# Altium schematic sheet 04_Analog_Part.SchDoc(04_Analog_Part)
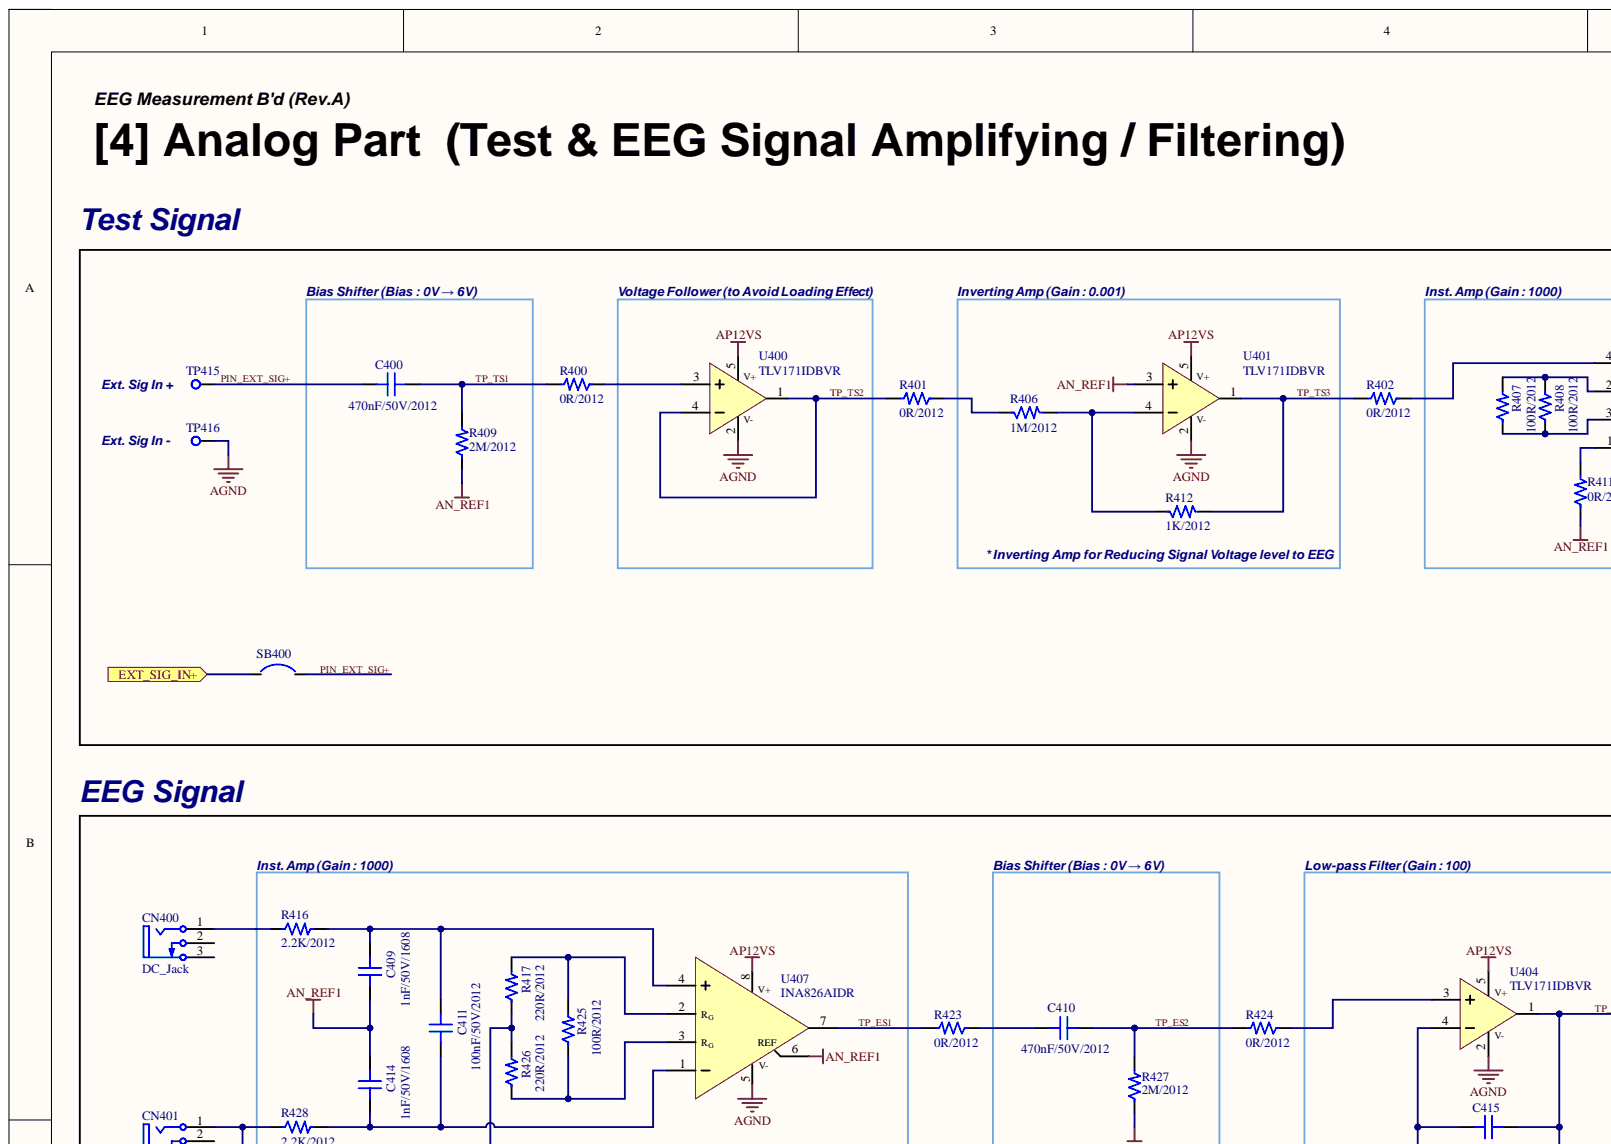
[diagram p0: 04_Analog_Part.SchDoc, top left]
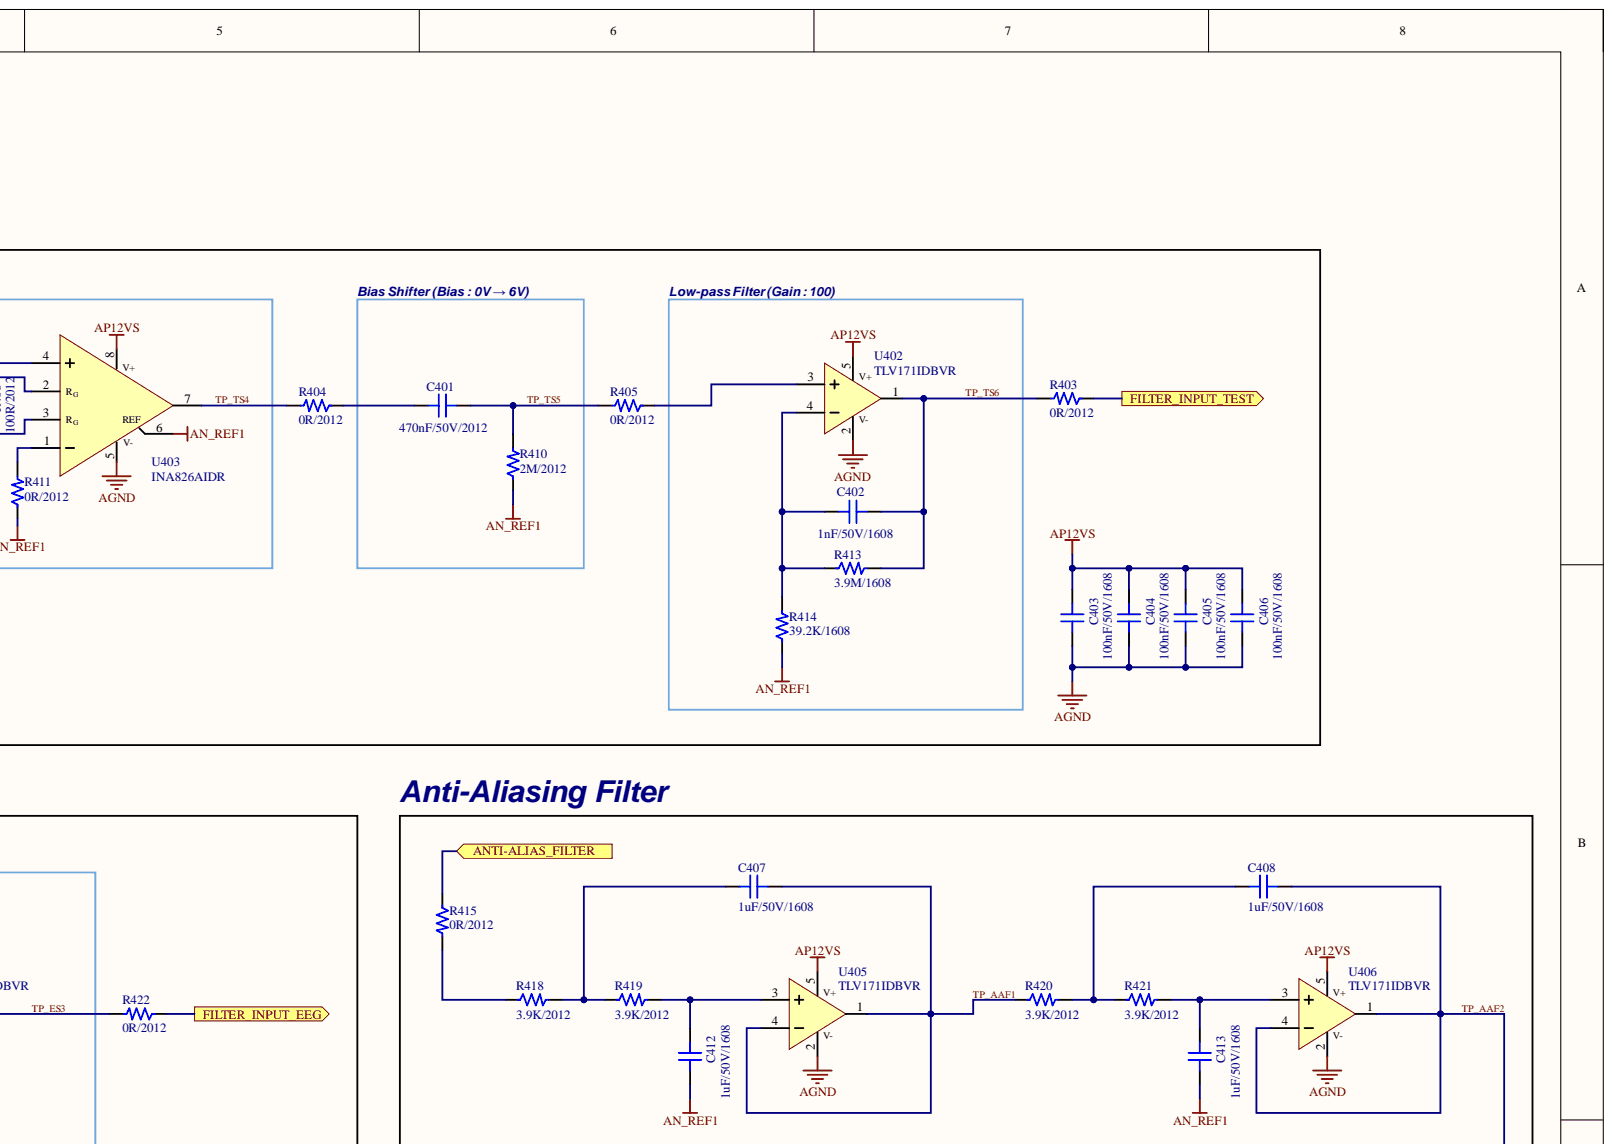
[diagram p1: 04_Analog_Part.SchDoc, top right]
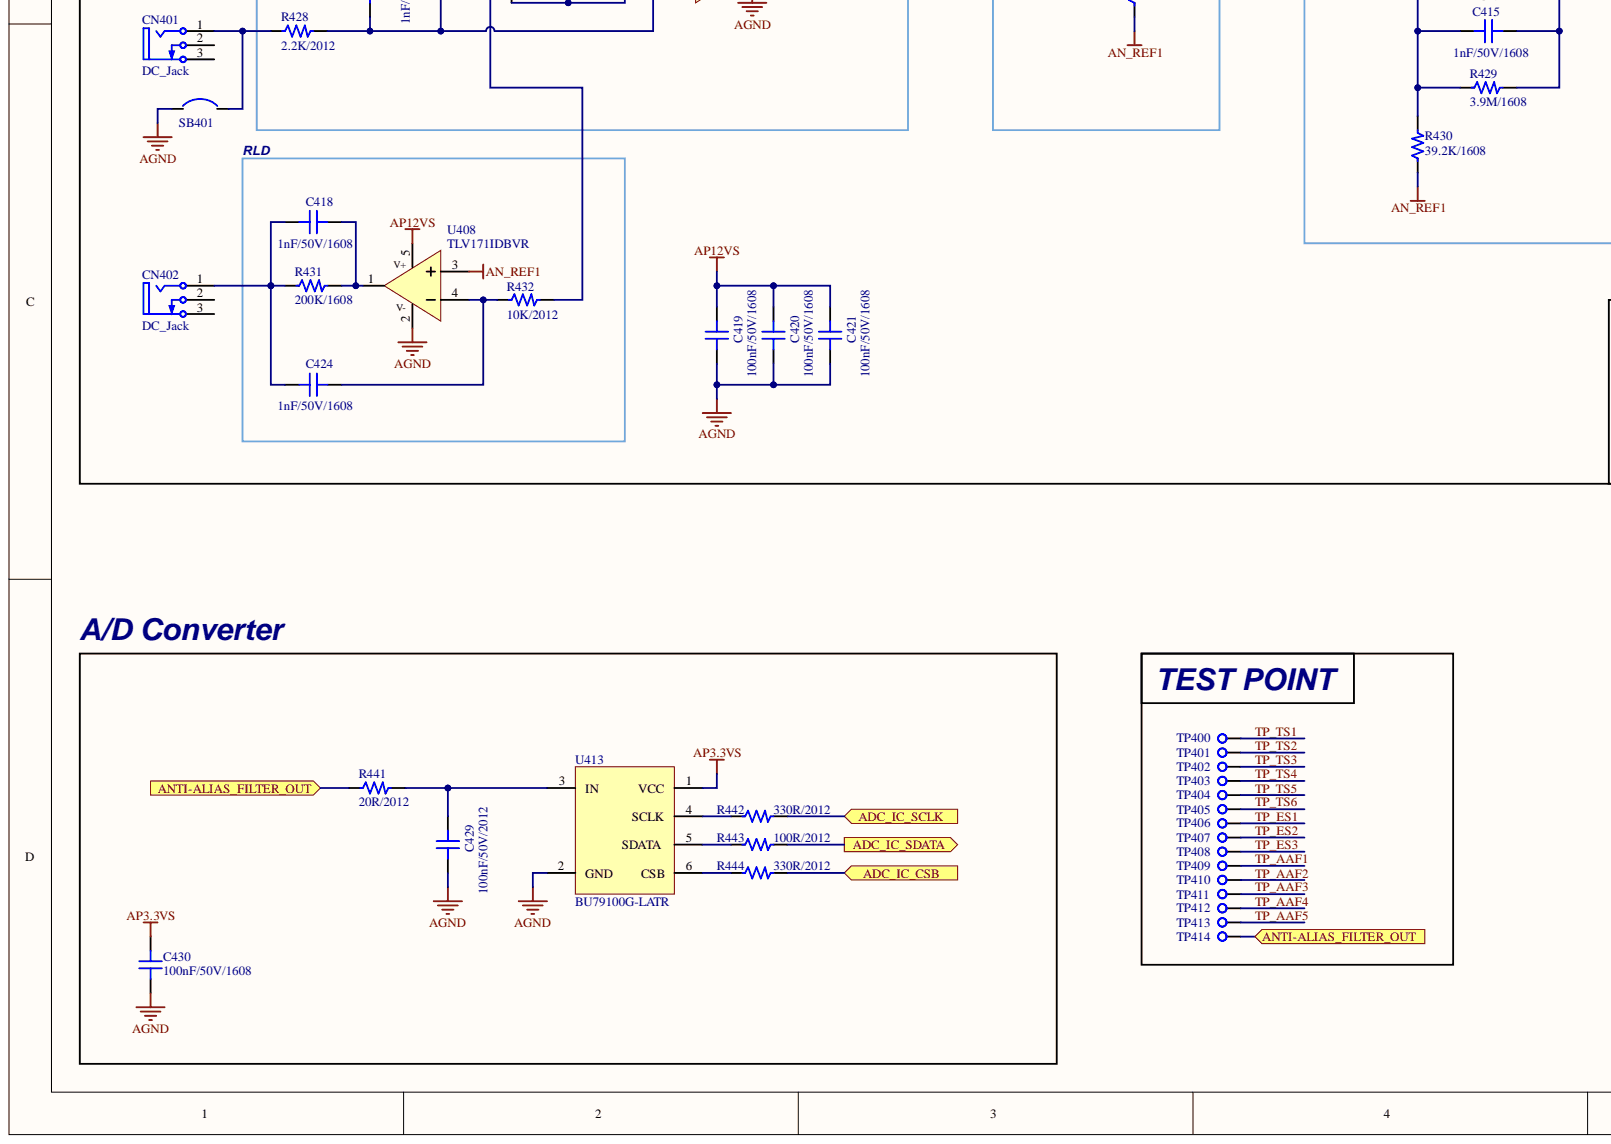
[diagram p2: 04_Analog_Part.SchDoc, bottom left]
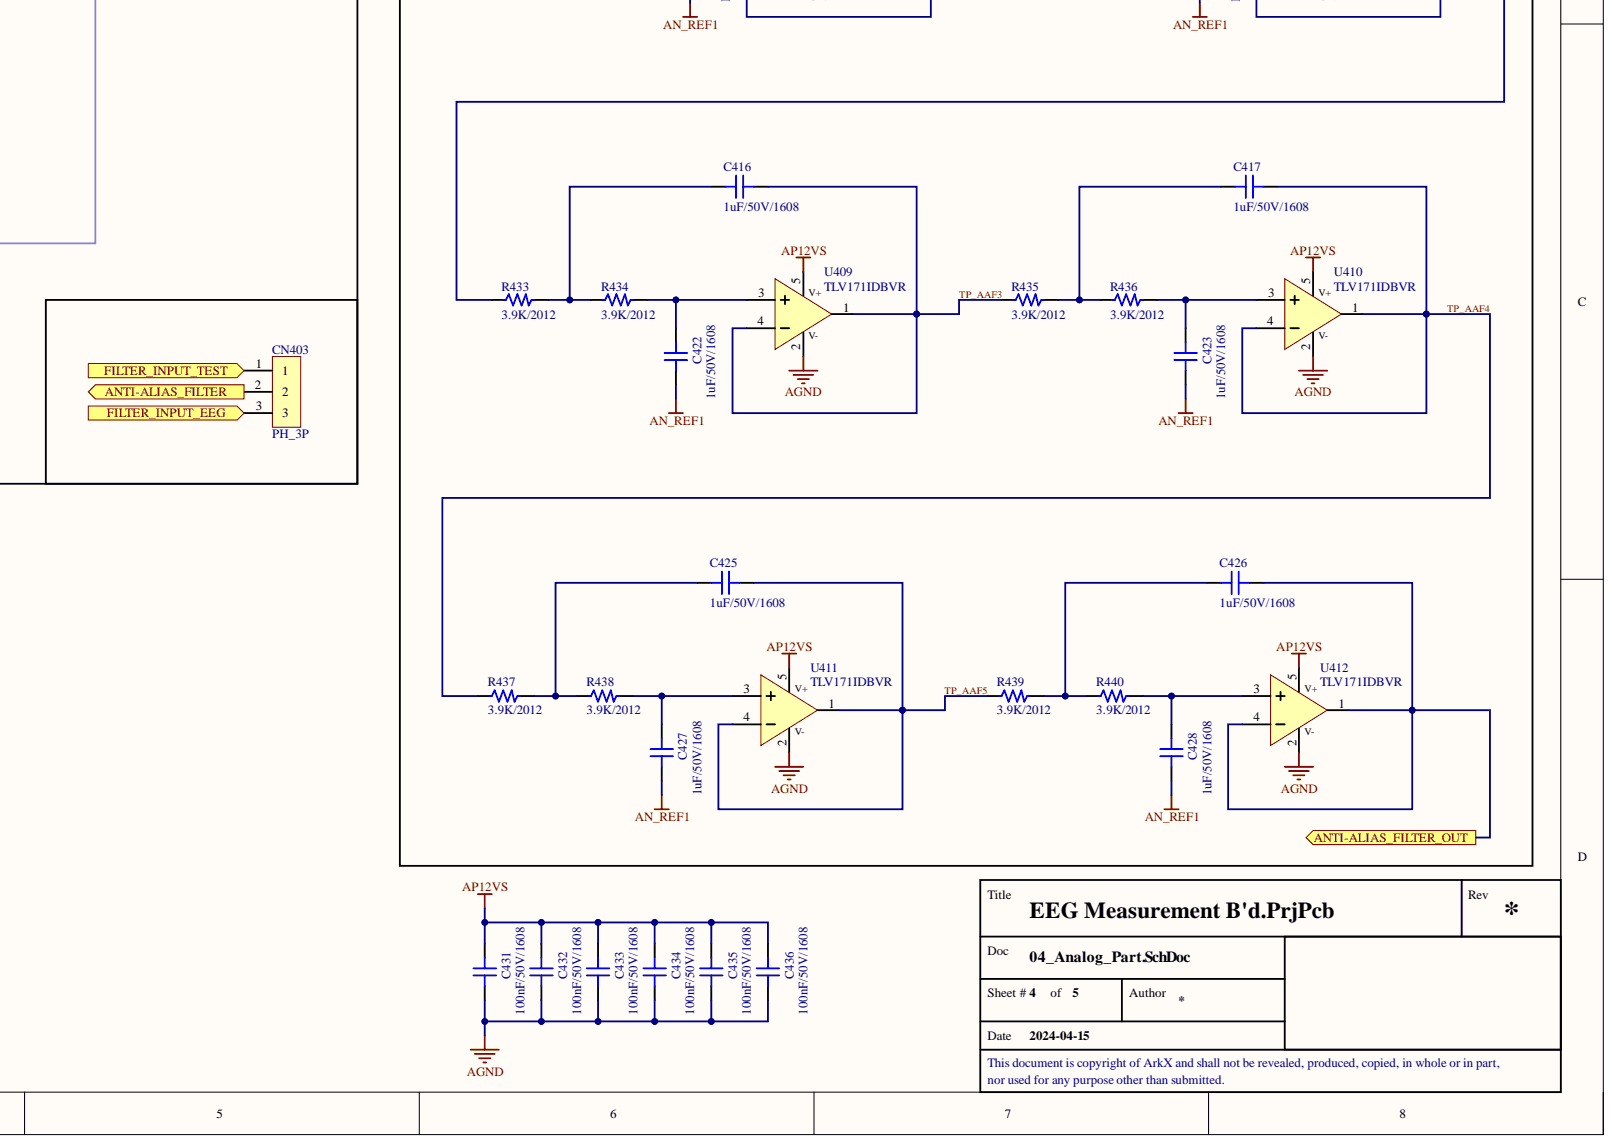
[diagram p3: 04_Analog_Part.SchDoc, bottom right]

## Parts
C400  470nF/50V/2012  [CAP 2012 (0805_INCH)]
C401  470nF/50V/2012  [CAP 2012 (0805_INCH)]
C402  1nF/50V/1608  [CAP 1608 (0603_INCH)]
C403  100nF/50V/1608  [CAP 1608 (0603_INCH)]
C404  100nF/50V/1608  [CAP 1608 (0603_INCH)]
C405  100nF/50V/1608  [CAP 1608 (0603_INCH)]
C406  100nF/50V/1608  [CAP 1608 (0603_INCH)]
C407  1uF/50V/1608  [CAP 1608 (0603_INCH)]
C408  1uF/50V/1608  [CAP 1608 (0603_INCH)]
C409  1nF/50V/1608  [CAP 1608 (0603_INCH)]
C410  470nF/50V/2012  [CAP 2012 (0805_INCH)]
C411  100nF/50V/2012  [CAP 2012 (0805_INCH)]
C412  1uF/50V/1608  [CAP 1608 (0603_INCH)]
C413  1uF/50V/1608  [CAP 1608 (0603_INCH)]
C414  1nF/50V/1608  [CAP 1608 (0603_INCH)]
C415  1nF/50V/1608  [CAP 1608 (0603_INCH)]
C416  1uF/50V/1608  [CAP 1608 (0603_INCH)]
C417  1uF/50V/1608  [CAP 1608 (0603_INCH)]
C418  1nF/50V/1608  [CAP 1608 (0603_INCH)]
C419  100nF/50V/1608  [CAP 1608 (0603_INCH)]
C420  100nF/50V/1608  [CAP 1608 (0603_INCH)]
C421  100nF/50V/1608  [CAP 1608 (0603_INCH)]
C422  1uF/50V/1608  [CAP 1608 (0603_INCH)]
C423  1uF/50V/1608  [CAP 1608 (0603_INCH)]
C424  1nF/50V/1608  [CAP 1608 (0603_INCH)]
C425  1uF/50V/1608  [CAP 1608 (0603_INCH)]
C426  1uF/50V/1608  [CAP 1608 (0603_INCH)]
C427  1uF/50V/1608  [CAP 1608 (0603_INCH)]
C428  1uF/50V/1608  [CAP 1608 (0603_INCH)]
C429  100nF/50V/2012  [CAP 2012 (0805_INCH)]
C430  100nF/50V/1608  [CAP 1608 (0603_INCH)]
C431  100nF/50V/1608  [CAP 1608 (0603_INCH)]
C432  100nF/50V/1608  [CAP 1608 (0603_INCH)]
C433  100nF/50V/1608  [CAP 1608 (0603_INCH)]
C434  100nF/50V/1608  [CAP 1608 (0603_INCH)]
C435  100nF/50V/1608  [CAP 1608 (0603_INCH)]
C436  100nF/50V/1608  [CAP 1608 (0603_INCH)]
CN400  DC_Jack  [CON DC-Jack]
CN401  DC_Jack  [CON DC-Jack]
CN402  DC_Jack  [CON DC-Jack]
CN403  PH_3P  [CON PIN_HEADER-3PIN(2.54MM)]
R400  0R/2012  [RES 2012 (0805_inch)]
R401  0R/2012  [RES 2012 (0805_inch)]
R402  0R/2012  [RES 2012 (0805_inch)]
R403  0R/2012  [RES 2012 (0805_inch)]
R404  0R/2012  [RES 2012 (0805_inch)]
R405  0R/2012  [RES 2012 (0805_inch)]
R406  1M/2012  [RES 2012 (0805_inch)]
R407  100R/2012  [RES 2012 (0805_inch)]
R408  100R/2012  [RES 2012 (0805_inch)]
R409  2M/2012  [RES 2012 (0805_inch)]
R410  2M/2012  [RES 2012 (0805_inch)]
R411  0R/2012  [RES 2012 (0805_inch)]
R412  1K/2012  [RES 2012 (0805_inch)]
R413  3.9M/1608  [RES 1608 (0603_inch)]
R414  39.2K/1608  [RES 1608 (0603_inch)]
R415  0R/2012  [RES 2012 (0805_inch)]
R416  2.2K/2012  [RES 2012 (0805_inch)]
R417  220R/2012  [RES 2012 (0805_inch)]
R418  3.9K/2012  [RES 2012 (0805_inch)]
R419  3.9K/2012  [RES 2012 (0805_inch)]
R420  3.9K/2012  [RES 2012 (0805_inch)]
R421  3.9K/2012  [RES 2012 (0805_inch)]
R422  0R/2012  [RES 2012 (0805_inch)]
R423  0R/2012  [RES 2012 (0805_inch)]
R424  0R/2012  [RES 2012 (0805_inch)]
R425  100R/2012  [RES 2012 (0805_inch)]
R426  220R/2012  [RES 2012 (0805_inch)]
R427  2M/2012  [RES 2012 (0805_inch)]
R428  2.2K/2012  [RES 2012 (0805_inch)]
R429  3.9M/1608  [RES 1608 (0603_inch)]
R430  39.2K/1608  [RES 1608 (0603_inch)]
R431  200K/1608  [RES 1608 (0603_inch)]
R432  10K/2012  [RES 2012 (0805_inch)]
R433  3.9K/2012  [RES 2012 (0805_inch)]
R434  3.9K/2012  [RES 2012 (0805_inch)]
R435  3.9K/2012  [RES 2012 (0805_inch)]
R436  3.9K/2012  [RES 2012 (0805_inch)]
R437  3.9K/2012  [RES 2012 (0805_inch)]
R438  3.9K/2012  [RES 2012 (0805_inch)]
R439  3.9K/2012  [RES 2012 (0805_inch)]
R440  3.9K/2012  [RES 2012 (0805_inch)]
R441  20R/2012  [RES 3216 (1206_inch)]
R442  330R/2012  [RES 2012 (0805_inch)]
R443  100R/2012  [RES 2012 (0805_inch)]
R444  330R/2012  [RES 2012 (0805_inch)]
SB400  SB  [SB (Solder-Bridge)]
SB401  SB  [SB (Solder-Bridge)]
TP400  TP_HOLE(1MM)  [TP_HOLE(1MM)]  (value not drawn)
TP401  TP_HOLE(1MM)  [TP_HOLE(1MM)]  (value not drawn)
TP402  TP_HOLE(1MM)  [TP_HOLE(1MM)]  (value not drawn)
TP403  TP_HOLE(1MM)  [TP_HOLE(1MM)]  (value not drawn)
TP404  TP_HOLE(1MM)  [TP_HOLE(1MM)]  (value not drawn)
TP405  TP_HOLE(1MM)  [TP_HOLE(1MM)]  (value not drawn)
TP406  TP_HOLE(1MM)  [TP_HOLE(1MM)]  (value not drawn)
TP407  TP_HOLE(1MM)  [TP_HOLE(1MM)]  (value not drawn)
TP408  TP_HOLE(1MM)  [TP_HOLE(1MM)]  (value not drawn)
TP409  TP_HOLE(1MM)  [TP_HOLE(1MM)]  (value not drawn)
TP410  TP_HOLE(1MM)  [TP_HOLE(1MM)]  (value not drawn)
TP411  TP_HOLE(1MM)  [TP_HOLE(1MM)]  (value not drawn)
TP412  TP_HOLE(1MM)  [TP_HOLE(1MM)]  (value not drawn)
TP413  TP_HOLE(1MM)  [TP_HOLE(1MM)]  (value not drawn)
TP414  TP_HOLE(1MM)  [TP_HOLE(1MM)]  (value not drawn)
TP415  TP_HOLE(1MM)  [TP_HOLE(1MM)]  (value not drawn)
TP416  TP_HOLE(1MM)  [TP_HOLE(1MM)]  (value not drawn)
U400  TLV171IDBVR  [SOT-23-5 (TI DBV PACKAGE)]
U401  TLV171IDBVR  [SOT-23-5 (TI DBV PACKAGE)]
U402  TLV171IDBVR  [SOT-23-5 (TI DBV PACKAGE)]
U403  INA826AIDR  [IC_SOIC-8 (4.90x3.90mm)]
U404  TLV171IDBVR  [SOT-23-5 (TI DBV PACKAGE)]
U405  TLV171IDBVR  [SOT-23-5 (TI DBV PACKAGE)]
U406  TLV171IDBVR  [SOT-23-5 (TI DBV PACKAGE)]
U407  INA826AIDR  [IC_SOIC-8 (4.90x3.90mm)]
U408  TLV171IDBVR  [SOT-23-5 (TI DBV PACKAGE)]
U409  TLV171IDBVR  [SOT-23-5 (TI DBV PACKAGE)]
U410  TLV171IDBVR  [SOT-23-5 (TI DBV PACKAGE)]
U411  TLV171IDBVR  [SOT-23-5 (TI DBV PACKAGE)]
U412  TLV171IDBVR  [SOT-23-5 (TI DBV PACKAGE)]
U413  BU79100G-LATR  [IC_SOT-23-6]

## Ports
ADC_IC_CSB, ADC_IC_SCLK, ADC_IC_SDATA, ANTI-ALIAS_FILTER, ANTI-ALIAS_FILTER_OUT, EXT_SIG_IN+, FILTER_INPUT_EEG, FILTER_INPUT_TEST

## Nets
AGND: C403.1 C404.1 C405.1 C406.1 C419.1 C420.1 C421.1 C429.1 C430.1 C431.1 C432.1 C433.1 C434.1 C435.1 C436.1 SB401.1 TP416.1 U400.2 U401.2 U402.2 U403.5 U404.2 U405.2 U406.2 U407.5 U408.2 U409.2 U410.2 U411.2 U412.2 U413.2(GND)
AN_REF1: C409.1 C412.1 C413.1 C414.2 C422.1 C423.1 C427.1 C428.1 R409.1 R410.1 R411.1 R414.1 R427.1 R430.1 U401.3 U403.6 U407.6 U408.3
AP3.3VS: C430.2 U413.1(VCC)
AP12VS: C403.2 C404.2 C405.2 C406.2 C419.2 C420.2 C421.2 C431.2 C432.2 C433.2 C434.2 C435.2 C436.2 U400.5 U401.5 U402.5 U403.8 U404.5 U405.5 U406.5 U407.8 U408.5 U409.5 U410.5 U411.5 U412.5
ADC_IC_CSB: R444.2 ; port ADC_IC_CSB
ADC_IC_SCLK: R442.2 ; port ADC_IC_SCLK
ADC_IC_SDATA: R443.2 ; port ADC_IC_SDATA
ANTI-ALIAS_FILTER: CN403.2 R415.1 ; port ANTI-ALIAS_FILTER
ANTI-ALIAS_FILTER_OUT: C426.2 R441.1 TP414.1 U412.1 U412.4 ; port ANTI-ALIAS_FILTER_OUT
EXT_SIG_IN+: SB400.1 ; port EXT_SIG_IN+
FILTER_INPUT_EEG: CN403.3 R422.1 ; port FILTER_INPUT_EEG
FILTER_INPUT_TEST: CN403.1 R403.1 ; port FILTER_INPUT_TEST
PIN_EXT_SIG+: C400.1 SB400.2 TP415.1
TP_AAF1: C407.2 R420.1 TP409.1 U405.1 U405.4
TP_AAF2: C408.2 R433.1 TP410.1 U406.1 U406.4
TP_AAF3: C416.2 R435.1 TP411.1 U409.1 U409.4
TP_AAF4: C417.2 R437.1 TP412.1 U410.1 U410.4
TP_AAF5: C425.2 R439.1 TP413.1 U411.1 U411.4
TP_ES1: R423.2 TP406.1 U407.7
TP_ES2: C410.2 R424.2 R427.2 TP407.1
TP_ES3: C415.1 R422.2 R429.2 TP408.1 U404.1
TP_TS1: C400.2 R400.2 R409.2 TP400.1
TP_TS2: R401.2 TP401.1 U400.1 U400.4
TP_TS3: R402.2 R412.2 TP402.1 U401.1
TP_TS4: R404.2 TP403.1 U403.7
TP_TS5: C401.2 R405.2 R410.2 TP404.1
TP_TS6: C402.1 R403.2 R413.2 TP405.1 U402.1
NetC401_1: C401.1 R404.1
NetC402_2: C402.2 R413.1 R414.2 U402.4
NetC407_1: C407.1 R418.2 R419.1
NetC408_1: C408.1 R420.2 R421.1
NetC409_2: C409.2 C411.2 R416.2 U407.4
NetC410_1: C410.1 R423.1
NetC411_1: C411.1 C414.1 R428.2 U407.1
NetC412_2: C412.2 R419.2 U405.3
NetC413_2: C413.2 R421.2 U406.3
NetC415_2: C415.2 R429.1 R430.2 U404.4
NetC416_1: C416.1 R433.2 R434.1
NetC417_1: C417.1 R435.2 R436.1
NetC418_1: C418.1 R431.2 U408.1
NetC418_2: C418.2 C424.2 CN402.1 R431.1
NetC422_2: C422.2 R434.2 U409.3
NetC423_2: C423.2 R436.2 U410.3
NetC424_1: C424.1 R432.1 U408.4
NetC425_1: C425.1 R437.2 R438.1
NetC426_1: C426.1 R439.2 R440.1
NetC427_2: C427.2 R438.2 U411.3
NetC428_2: C428.2 R440.2 U412.3
NetC429_2: C429.2 R441.2 U413.3(IN)
NetCN400_1: CN400.1 R416.1
NetCN401_1: CN401.1 R428.1 SB401.2
NetR400_1: R400.1 U400.3
NetR401_1: R401.1 R406.1
NetR402_1: R402.1 U403.4
NetR405_1: R405.1 U402.3
NetR406_2: R406.2 R412.1 U401.4
NetR407_1: R407.1 R408.1 U403.3(R)
NetR407_2: R407.2 R408.2 U403.2(R)
NetR411_2: R411.2 U403.1
NetR415_2: R415.2 R418.1
NetR417_1: R417.1 R426.2 R432.2
NetR417_2: R417.2 R425.2 U407.2(R)
NetR424_1: R424.1 U404.3
NetR425_1: R425.1 R426.1 U407.3(R)
NetR442_1: R442.1 U413.4(SCLK)
NetR443_1: R443.1 U413.5(SDATA)
NetR444_1: R444.1 U413.6(CSB)

Not connected: CN400 2 3; CN401 2 3; CN402 2 3

## Library data of the parts
C400 C401 C410 = CAP_470nF/50V/2012: Ceramic CAP_470nF/50V/2012; Component Kind: Standard; JLCPCB Part Type: Basic Part; LCSC Part #: C13967; Library Name: Arkx.IntLib
C402 C409 C414 C415 C418 C424 = CAP_1nF/50V/1608: Ceramic CAP_1nF/50V/1608; Component Kind: Standard; JLCPCB Part Type: Basic Part; LCSC Part #: C1588; Library Name: Arkx.IntLib
C403-C406 C419-C421 C430-C436 = CAP_100nF/50V/1608: Ceramic CAP_100nF/50V/1608; Component Kind: Standard; JLCPCB Part Type: Basic Part; LCSC Part #: C14663; Library Name: Arkx.IntLib
C407 C408 C412 C413 C416 C417 C422 C423 C425-C428 = CAP_1uF/50V/1608: Ceramic CAP_1uF/50V/1608; Component Kind: Standard; JLCPCB Part Type: Basic Part; LCSC Part #: C15849; Library Name: Arkx.IntLib
C411 C429 = CAP_100nF/50V/2012: Ceramic CAP_100nF/50V/2012; Component Kind: Standard; JLCPCB Part Type: Basic Part; LCSC Part #: C49678; Library Name: Arkx.IntLib
CN400-CN402 = CON_DC-Jack: Component Kind: Standard; ComponentLink1Description: Manufacturer Link; ComponentLink1URL: http://www.cliffuk.co.uk/; Description: DC_Jack; Library Name: Arkx.IntLib
CN403 = CON_PIN_HEADER_SINGLE-3PIN(2.54MM): Component Kind: Standard; Description: CON_PIN_HEADER_SINGLE-3PIN(2.54MM); Library Name: Arkx.IntLib
R400-R405 R411 R415 R422-R424 = RES_0R/2012/0.125W: Power Rating: 0.125W; Precision: 1%; Value: 0R; Component Kind: Standard; Description: RES_0R/2012/0.125W; JLCPCB Part Type: Basic Part; LCSC Part #: C17477; Size: 2012; Library Name: Arkx.IntLib
R406 = RES_1M/2012/0.125W: Power Rating: 0.125W; Precision: 1%; Value: 1M; Component Kind: Standard; Description: RES_1M/2012/0.125W; JLCPCB Part Type: Basic Part; LCSC Part #: C17514; Size: 2012; Library Name: Arkx.IntLib
R407 R408 R425 R443 = RES_100R/2012/0.125W: Power Rating: 0.125W; Precision: 1%; Value: 100R; Component Kind: Standard; Description: RES_100R/2012/0.125W; JLCPCB Part Type: Basic Part; LCSC Part #: C17408; Size: 2012; Library Name: Arkx.IntLib
R409 R410 R427 = RES_2M/2012/0.125W: Power Rating: 0.125W; Precision: 1%; Value: 2M; Component Kind: Standard; Description: RES_2M/2012/0.125W; JLCPCB Part Type: Basic Part; LCSC Part #: C26112; Size: 2012; Library Name: Arkx.IntLib
R412 = RES_1K/2012/0.125W: Power Rating: 0.125W; Precision: 1%; Value: 1K; Component Kind: Standard; Description: RES_1K/2012/0.125W; JLCPCB Part Type: Basic Part; LCSC Part #: C17513; Size: 2012; Library Name: Arkx.IntLib
R413 R429 = RES_3.9M/1608/0.1W: Power Rating: 0.1W; Precision: 1%; Value: 3.9M; Component Kind: Standard; Description: RES_3.9M/1608/0.1W; JLCPCB Part Type: Extended Part; LCSC Part #: C227875; Size: 1608; Library Name: Arkx.IntLib
R414 R430 = RES_39.2K/1608/0.1W: Power Rating: 0.1W; Precision: 1%; Value: 39.2K; Component Kind: Standard; Description: RES_39.2K/1608/0.1W; JLCPCB Part Type: Extended Part; LCSC Part #: C137734; Size: 1608; Library Name: Arkx.IntLib
R416 R428 = RES_2.2K/2012/0.125W: Power Rating: 0.125W; Precision: 1%; Value: 2.2K; Component Kind: Standard; Description: RES_2.2K/2012/0.125W; JLCPCB Part Type: Basic Part; LCSC Part #: C17520; Size: 2012; Library Name: Arkx.IntLib
R417 R426 = RES_220R/2012/0.125W: Power Rating: 0.125W; Precision: 1%; Value: 220R; Component Kind: Standard; Description: RES_220R/2012/0.125W; JLCPCB Part Type: Basic Part; LCSC Part #: C17557; Size: 2012; Library Name: Arkx.IntLib
R418-R421 R433-R440 = RES_3.9K/2012/0.125W: Power Rating: 0.125W; Precision: 1%; Value: 3.9K; Component Kind: Standard; Description: RES_3.9K/2012/0.125W; JLCPCB Part Type: Basic Part; LCSC Part #: C17614; Size: 2012; Library Name: Arkx.IntLib
R431 = RES_200K/1608/0.1W: Power Rating: 0.1W; Precision: 1%; Value: 200K; Component Kind: Standard; Description: RES_200K/1608/0.1W; JLCPCB Part Type: Basic Part; LCSC Part #: C25811; Size: 1608; Library Name: Arkx.IntLib
R432 = RES_10K/2012/0.125W: Power Rating: 0.125W; Precision: 1%; Value: 10K; Component Kind: Standard; Description: RES_10K/2012/0.125W; JLCPCB Part Type: Basic Part; LCSC Part #: C17414; Size: 2012; Library Name: Arkx.IntLib
R441 = RES_20R/2012/0.125W: Power Rating: 0.125W; Precision: 1%; Value: 20R; Component Kind: Standard; Description: RES_20R/2012/0.125W; JLCPCB Part Type: Basic Part; LCSC Part #: C17544; Size: 2012; Library Name: Arkx.IntLib
R442 R444 = RES_330R/2012/0.125W: Power Rating: 0.125W; Precision: 1%; Value: 330R; Component Kind: Standard; Description: RES_330R/2012/0.125W; JLCPCB Part Type: Basic Part; LCSC Part #: C17630; Size: 2012; Library Name: Arkx.IntLib
SB400 SB401 = SB_Solder-Bridge: Component Kind: Standard; Description: SB_Solder-Bridge; Library Name: Arkx.IntLib
U400-U402 U404-U406 U408-U412 = IC_OPAMP_TLV171IDBVR: Single, 36-V, 3-MHz, low-power operational amplifier for cost-sensitive applications; Component Kind: Standard; JLCPCB Part Type: Extended Part; LCSC Part #: C2864299; Library Name: Arkx.IntLib
U403 U407 = IC_INST-AMP_INA826AIDR: 35nA 40uV 1MHz Single SOIC-8  Instrumentation OpAmps ROHS; Component Kind: Standard; JLCPCB Part Type: Extended Part; LCSC Part #: C38433; Library Name: Arkx.IntLib
U413 = IC_ADC_BU79100G-LATR: Successive Approximation A/D Converter  12 bit, 0.5 MSPS to 1 MSPS, 2.7 V to 5.25 V,  1-channel, SPI Interface; Component Kind: Standard; JLCPCB Part Type: None; LCSC Part #: None; Library Name: Arkx.IntLib
TP400-TP416 = TP_HOLE(1MM): Component Kind: Standard; Description: TP_HOLE(1MM); Library Name: Arkx.IntLib
